# Altium schematic sheet PIO_Test.SchDoc
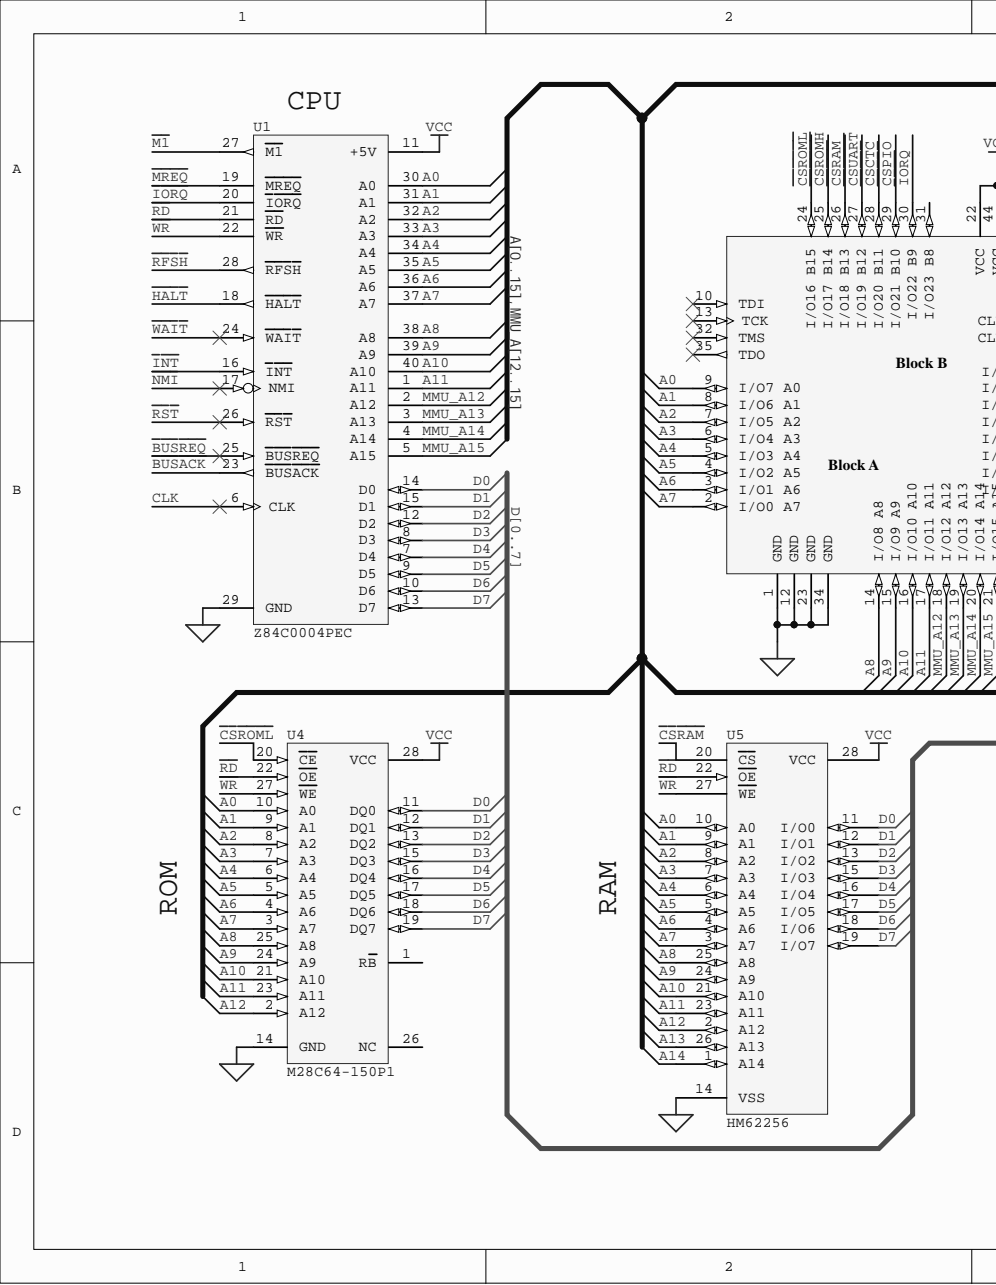
[diagram p0: PIO_Test.SchDoc, left]
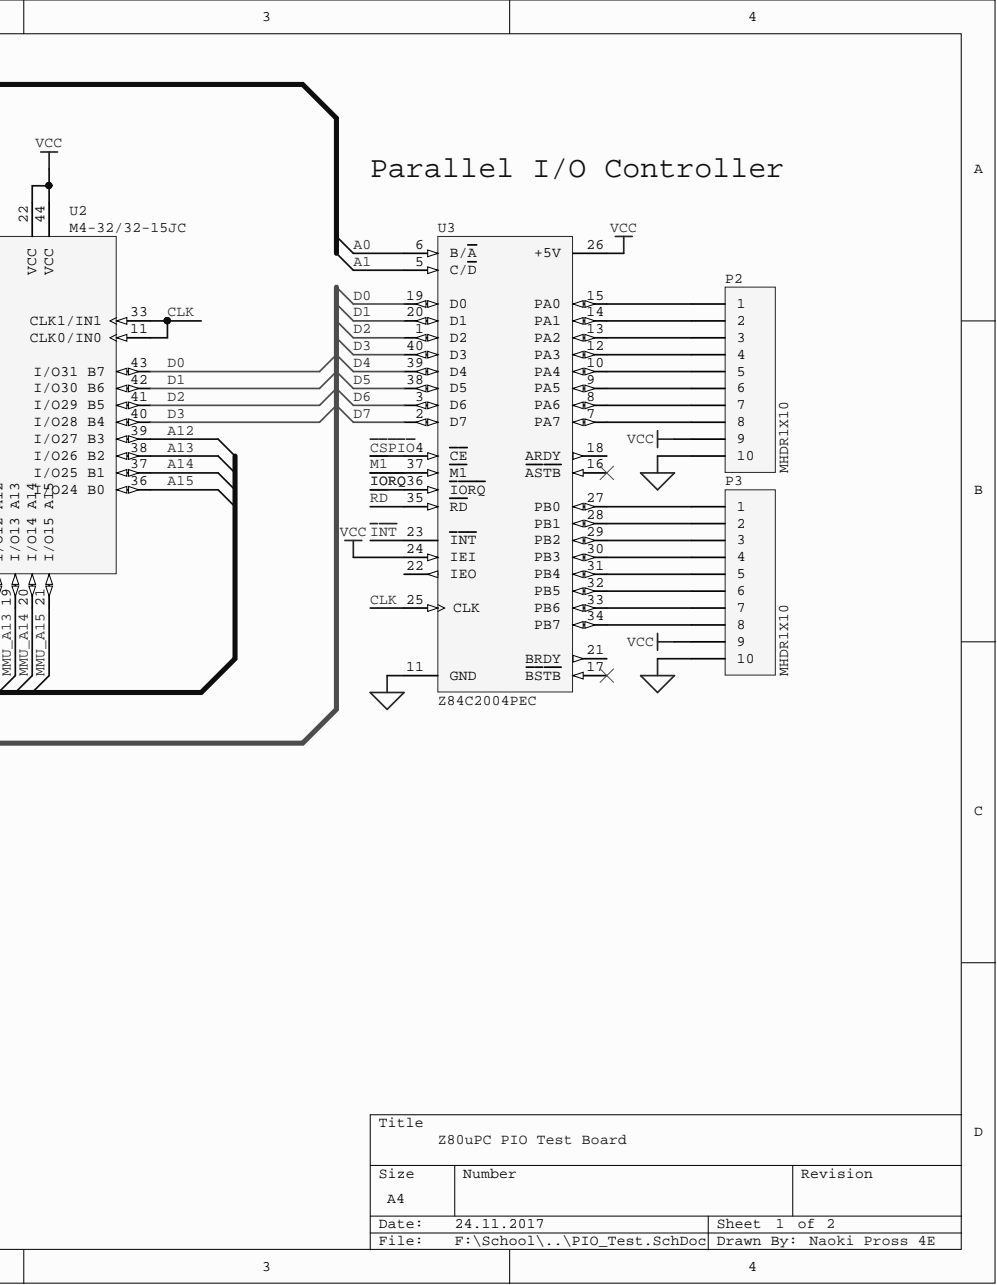
[diagram p1: PIO_Test.SchDoc, right]

## Parts
P2  MHDR1X10  [HDR5x2_SOCK]
P3  MHDR1X10  [HDR5x2_SOCK]
U1  Z84C0004PEC  [PDIP40]
U2  M4-32/32-15JC  [PLCC44_SOCKET]
U3  Z84C2004PEC  [PDIP40]
U4  M28C64-150P1  [PDIP28]
U5  HM62256  [PDIP28]

## Nets
GND: P2.10 P3.10 U1.29(GND) U2.1(GND) U2.12(GND) U2.23(GND) U2.34(GND) U3.11(GND) U4.14(GND) U5.14(VSS)
VCC: P2.9 P3.9 U1.11(+5V) U2.22(VCC) U2.44(VCC) U3.24(IEI) U3.26(+5V) U4.28(VCC) U5.28(VCC)
A0: U1.30(A0) U2.9(I/O7) U3.6(B/A) U4.10(A0) U5.10(A0)  [also labelled A]
A1: U1.31(A1) U2.8(I/O6) U3.5(C/D) U4.9(A1) U5.9(A1)  [also labelled A]
A2: U1.32(A2) U2.7(I/O5) U4.8(A2) U5.8(A2)  [also labelled A]
A3: U1.33(A3) U2.6(I/O4) U4.7(A3) U5.7(A3)  [also labelled A]
A4: U1.34(A4) U2.5(I/O3) U4.6(A4) U5.6(A4)  [also labelled A]
A5: U1.35(A5) U2.4(I/O2) U4.5(A5) U5.5(A5)  [also labelled A]
A6: U1.36(A6) U2.3(I/O1) U4.4(A6) U5.4(A6)  [also labelled A]
A7: U1.37(A7) U2.2(I/O0) U4.3(A7) U5.3(A7)  [also labelled A]
A8: U1.38(A8) U2.14(I/O8) U4.25(A8) U5.25(A8)  [also labelled A]
A9: U1.39(A9) U2.15(I/O9) U4.24(A9) U5.24(A9)  [also labelled A]
A10: U1.40(A10) U2.16(I/O10) U4.21(A10) U5.21(A10)  [also labelled A]
A11: U1.1(A11) U2.17(I/O11) U4.23(A11) U5.23(A11)  [also labelled A]
A12: U2.39(B3) U4.2(A12) U5.2(A12)  [also labelled A]
A13: U2.38(B2) U5.26(A13)  [also labelled A]
A14: U2.37(B1) U5.1(A14)  [also labelled A]
A15: U2.36(B0)  [also labelled A]
~{BUSACK}: U1.23(BUSACK)
~{BUSREQ}: U1.25(BUSREQ)
~{CSCTC}: U2.28(B11)
~{CSPIO}: U2.29(B10) U3.4(CE)
~{CSRAM}: U2.26(B13) U5.20(CS)
~{CSROMH}: U2.25(B14)
~{CSROML}: U2.24(B15) U4.20(CE)
~{CSUART}: U2.27(B12)
CLK: U1.6(CLK) U2.11(CLK0/IN0) U2.33(CLK1/IN1) U3.25(CLK)
D0: U1.14(D0) U2.43(B7) U3.19(D0) U4.11(DQ0) U5.11(I/O0)  [also labelled D]
D1: U1.15(D1) U2.42(B6) U3.20(D1) U4.12(DQ1) U5.12(I/O1)  [also labelled D]
D2: U1.12(D2) U2.41(B5) U3.1(D2) U4.13(DQ2) U5.13(I/O2)  [also labelled D]
D3: U1.8(D3) U2.40(B4) U3.40(D3) U4.15(DQ3) U5.15(I/O3)  [also labelled D]
D4: U1.7(D4) U3.39(D4) U4.16(DQ4) U5.16(I/O4)  [also labelled D]
D5: U1.9(D5) U3.38(D5) U4.17(DQ5) U5.17(I/O5)  [also labelled D]
D6: U1.10(D6) U3.3(D6) U4.18(DQ6) U5.18(I/O6)  [also labelled D]
D7: U1.13(D7) U3.2(D7) U4.19(DQ7) U5.19(I/O7)  [also labelled D]
~{HALT}: U1.18(HALT)
~{INT}: U1.16(INT) U3.23(INT)
~{IORQ}: U1.20(IORQ) U2.30(B9) U3.36(IORQ)
~{M1}: U1.27(M1) U3.37(M1)
~{MREQ}: U1.19(MREQ)
MMU_A12: U1.2(A12) U2.18(I/O12)
MMU_A13: U1.3(A13) U2.19(I/O13)
MMU_A14: U1.4(A14) U2.20(I/O14)
MMU_A15: U1.5(A15) U2.21(I/O15)
~{NMI}: U1.17(NMI)
~{RD}: U1.21(RD) U3.35(RD) U4.22(OE) U5.22(OE)
~{RFSH}: U1.28(RFSH)
~{RST}: U1.26(RST)
~{WAIT}: U1.24(WAIT)
~{WR}: U1.22(WR) U4.27(WE) U5.27(WE)
NetP2_1: P2.1 U3.15(PA0)
NetP2_2: P2.2 U3.14(PA1)
NetP2_3: P2.3 U3.13(PA2)
NetP2_4: P2.4 U3.12(PA3)
NetP2_5: P2.5 U3.10(PA4)
NetP2_6: P2.6 U3.9(PA5)
NetP2_7: P2.7 U3.8(PA6)
NetP2_8: P2.8 U3.7(PA7)
NetP3_1: P3.1 U3.27(PB0)
NetP3_2: P3.2 U3.28(PB1)
NetP3_3: P3.3 U3.29(PB2)
NetP3_4: P3.4 U3.30(PB3)
NetP3_5: P3.5 U3.31(PB4)
NetP3_6: P3.6 U3.32(PB5)
NetP3_7: P3.7 U3.33(PB6)
NetP3_8: P3.8 U3.34(PB7)

Not connected: U2 10(TDI) 13(TCK) 31(B8) 32(TMS) 35(TDO); U3 16(ASTB) 17(BSTB) 18(ARDY) 21(BRDY) 22(IEO); U4 1(RB) 26(NC)

## Library data of the parts
P2 P3 = MHDR1X10: Header, 10-Pin; Component Kind: Standard; LatestRevisionDate: 17-Jul-2002; LatestRevisionNote: Re-released for DXP Platform.; Library Name: Miscellaneous Connectors.IntLib; Signal Integrity: Connector; Publisher: Altium Limited
U1 = Z84C0004PEC: CMOS 8-Bit Microprocessor; Component Kind: Standard; ComponentLink1Description: Manufacturer Link; ComponentLink1URL: http://www.zilog.com/; DatasheetVersion: Jan-1989; LatestRevisionDate: 04-Mar-2005; LatestRevisionNote: Stylized 3D Model Added.; PackageDescription: DIP; 40 Leads; Row Spacing 15.24 mm; Pitch 2.54 mm; PackageReference: PDIP40; PackageVersion: Jun-1999; PCB3D: PDIP40; Published: 15-Apr-2002; Library Name: Zilog Microprocessor 8-Bit.IntLib; Publisher: Altium Limited
U2 = M4-32/32-15JC: High-Performance EE CMOS In-System Programmable Logic; Code_IPC: PLCC-44; Component Kind: Standard; ComponentLink1Description: Manufacturer Link; ComponentLink1URL: http://www.latticesemi.com/products/mature/index.cfm; ComponentLink2Description: Datasheet; ComponentLink2URL: http://www.latticesemi.com/lit/docs/datasheets/cpld/mach4.pdf; DatasheetVersion: Mar-2000; LatestRevisionDate: 13-Apr-2006; LatestRevisionNote: IPC-7351 Footprint Added.; PackageDescription: 44-Pin Leaded Chip Carrier 1.27 mm Pitch; PackageReference: PLCC44; PackageVersion: pkg_32, Aug-2005; PCB3D: M4-PLCC44; Published: 23-Sep-2002; Library Name: Lattice CPLD ispMACH.IntLib; Publisher: Altium Limited
U3 = Z84C2004PEC: CMOS Parallel I/O Controller; Component Kind: Standard; ComponentLink1Description: Manufacturer Link; ComponentLink1URL: http://www.zilog.com/; ComponentLink2Description: Datasheet; ComponentLink2URL: http://www.zilog.com/docs/z80/um0081.pdf; DatasheetVersion: 2001; LatestRevisionDate: 04-Mar-2005; LatestRevisionNote: Stylized 3D Model Added.; PackageDescription: DIP; 40 Leads; Row Spacing 15.24 mm; Pitch 2.54 mm; PackageReference: PDIP40; PackageVersion: Jun-1999; PCB3D: PDIP40; Published: 15-Apr-2002; Library Name: Zilog Peripheral Interface.IntLib; Publisher: Altium Limited
U4 = M28C64-150P1: Parallel 64 Kbit (8K x 8) EEPROM with Software Data Protection; Component Kind: Standard; ComponentLink1Description: Manufacturer Link; ComponentLink1URL: http://www.st.com/; DatasheetVersion: Jun-1996; LatestRevisionDate: 06-Jun-2005; LatestRevisionNote: Stylized 3D Model Added.; Library Name: ST Memory EEPROM Parallel.IntLib; PackageDescription: DIP; 28 Leads; Row Spacing 15.24 mm; Pitch 2.54 mm; PackageReference: PDIP28; PackageVersion: Jan-2003; PCB3D: PDIP28; Published: 24-Aug-2001; Publisher: Altium Limited
U5 = HM62256: 8K x 8 Static RAM; Component Kind: Standard; Library Name: HITACHI Memory Non-Volatile RAM.SchLib
